# Altium schematic sheet ZYNQ_PS_BANK.SchDoc(ZYNQ_PS_BANK)
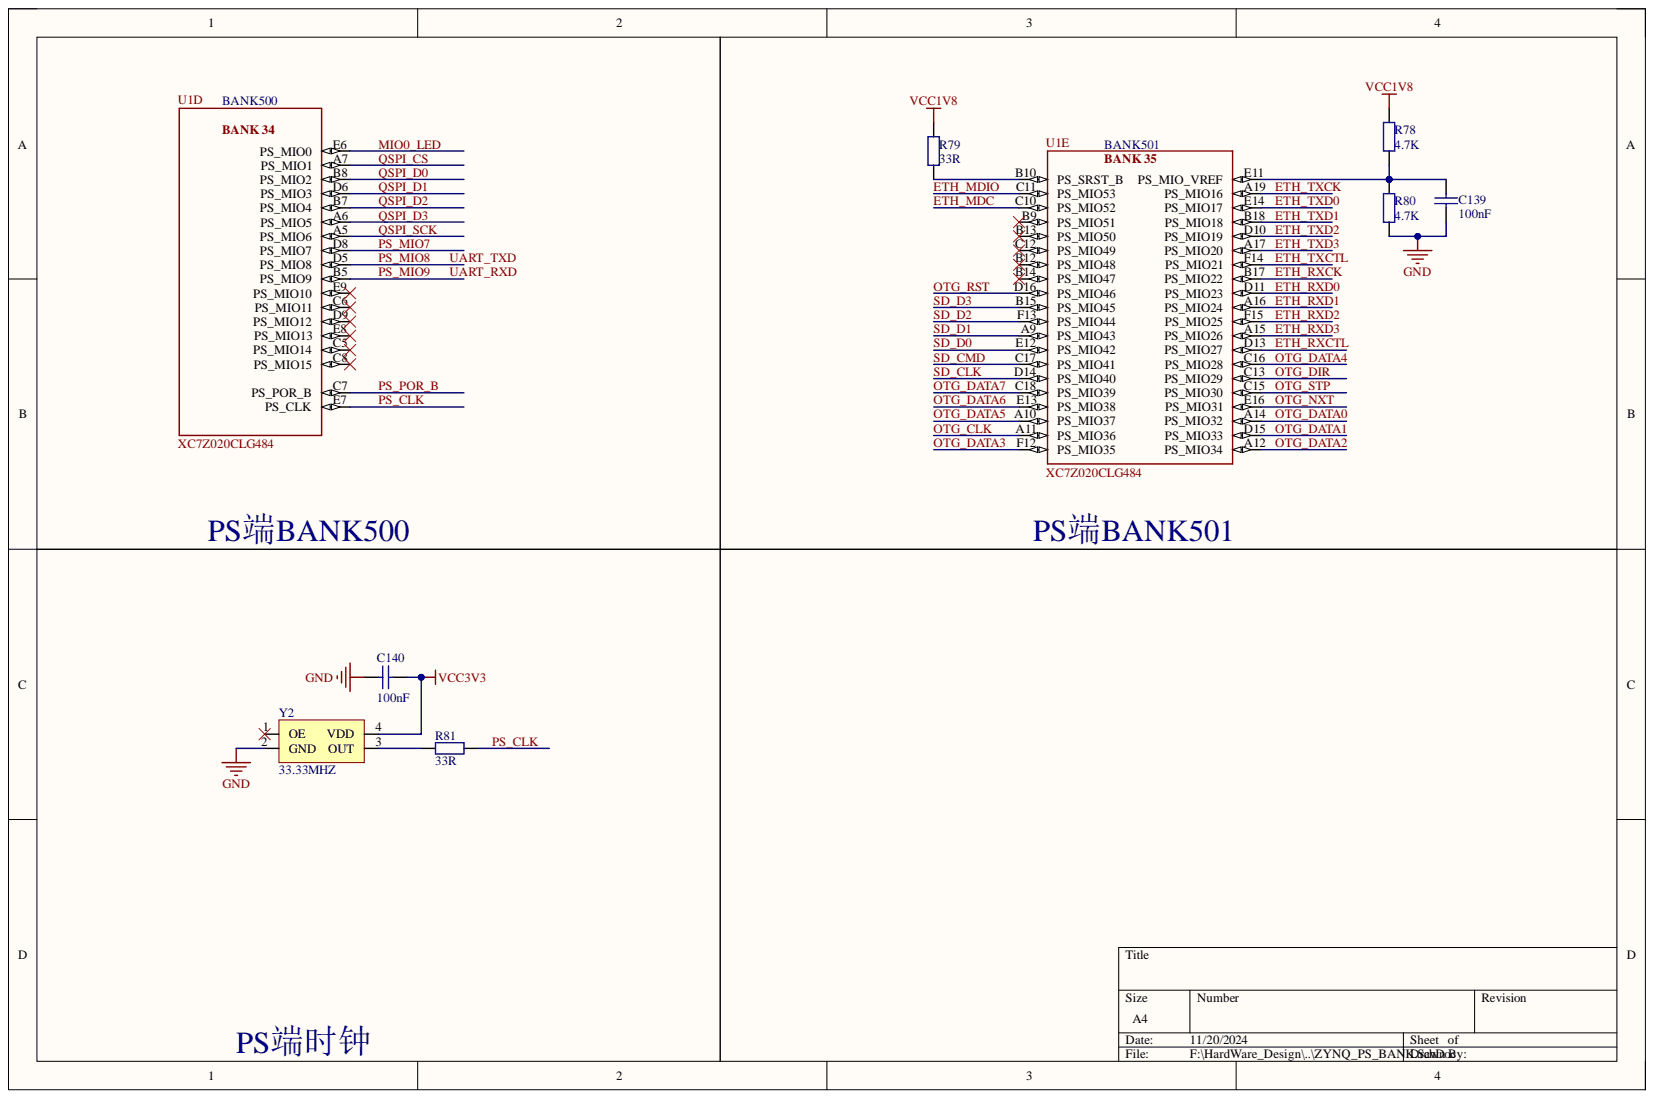
[diagram p0: ZYNQ_PS_BANK.SchDoc]

## Parts
C139  100nF  [C 0402_L]
C140  100nF  [C 0402_L]
R78  4.7K  [R 0402_L]
R79  33R  [R 0402_L]
R80  4.7K  [R 0402_L]
R81  33R  [R 0402_L]
U1  XC7Z020CLG484  [BGA400C80P20X20_1700X1700X160]  (parts D,E)
Y2  33.3M  value 33.33MHZ  [SMD2016M4]

## Nets
GND: C139.1 C140.1 R80.1 Y2.2(GND)
VCC1V8: R78.2 R79.2
VCC3V3: C140.2 Y2.4(VDD)
ETH_MDC: U1.C10(PS_MIO52)
ETH_MDIO: U1.C11(PS_MIO53)
ETH_RXCK: U1.B17(PS_MIO22)
ETH_RXCTL: U1.D13(PS_MIO27)
ETH_RXD0: U1.D11(PS_MIO23)
ETH_RXD1: U1.A16(PS_MIO24)
ETH_RXD2: U1.F15(PS_MIO25)
ETH_RXD3: U1.A15(PS_MIO26)
ETH_TXCK: U1.A19(PS_MIO16)
ETH_TXCTL: U1.F14(PS_MIO21)
ETH_TXD0: U1.E14(PS_MIO17)
ETH_TXD1: U1.B18(PS_MIO18)
ETH_TXD2: U1.D10(PS_MIO19)
ETH_TXD3: U1.A17(PS_MIO20)
MIO0_LED: U1.E6(PS_MIO0)
OTG_CLK: U1.A11(PS_MIO36)
OTG_DATA0: U1.A14(PS_MIO32)
OTG_DATA1: U1.D15(PS_MIO33)
OTG_DATA2: U1.A12(PS_MIO34)
OTG_DATA3: U1.F12(PS_MIO35)
OTG_DATA4: U1.C16(PS_MIO28)
OTG_DATA5: U1.A10(PS_MIO37)
OTG_DATA6: U1.E13(PS_MIO38)
OTG_DATA7: U1.C18(PS_MIO39)
OTG_DIR: U1.C13(PS_MIO29)
OTG_NXT: U1.E16(PS_MIO31)
OTG_RST: U1.D16(PS_MIO46)
OTG_STP: U1.C15(PS_MIO30)
PS_CLK: R81.1 U1.E7(PS_CLK)
PS_MIO7: U1.D8(PS_MIO7)
PS_MIO8: U1.D5(PS_MIO8)
PS_MIO9: U1.B5(PS_MIO9)
PS_POR_B: U1.C7(PS_POR_B)
QSPI_CS: U1.A7(PS_MIO1)
QSPI_D0: U1.B8(PS_MIO2)
QSPI_D1: U1.D6(PS_MIO3)
QSPI_D2: U1.B7(PS_MIO4)
QSPI_D3: U1.A6(PS_MIO5)
QSPI_SCK: U1.A5(PS_MIO6)
SD_CLK: U1.D14(PS_MIO40)
SD_CMD: U1.C17(PS_MIO41)
SD_D0: U1.E12(PS_MIO42)
SD_D1: U1.A9(PS_MIO43)
SD_D2: U1.F13(PS_MIO44)
SD_D3: U1.B15(PS_MIO45)
NetC139_2: C139.2 R78.1 R80.2 U1.E11(PS_MIO_VREF)
NetR79_1: R79.1 U1.B10(PS_SRST_B)
NetR81_2: R81.2 Y2.3(OUT)

Not connected: U1 B9(PS_MIO51) B12(PS_MIO48) B13(PS_MIO50) B14(PS_MIO47) C5(PS_MIO14) C6(PS_MIO11) C8(PS_MIO15) C12(PS_MIO49) D9(PS_MIO12) E8(PS_MIO13) E9(PS_MIO10); Y2 1(OE)

## Library data of the parts
C139 C140 = Cap1: Component Kind: Standard; value: 100nF; Library Name: 零散元件库2.SCHLIB
R78-R81 = Res_1: Component Kind: Standard; Library Name: 零散元件库2.SCHLIB
  R78 R80: value: 4.7K
  R79 R81: value: 33R
U1 = XC7Z020: Zynq 7000 FPGA 20 Die, in a CSG 484 Package; Manufacturer: Xilinx; Component Kind: Standard; Library Name: ZYNQ_PCB.SCHLIB
Y2 = XATLS: 贴片有源晶振; Component Kind: Standard; Library Name: 2.0 - 保险丝-晶振-光耦.SchLib; 封装库来自淘宝卖家 ID：黄晶宝石: https://shop463601444.taobao.com
